# Altium schematic sheet SNP.SchDoc(SNP)
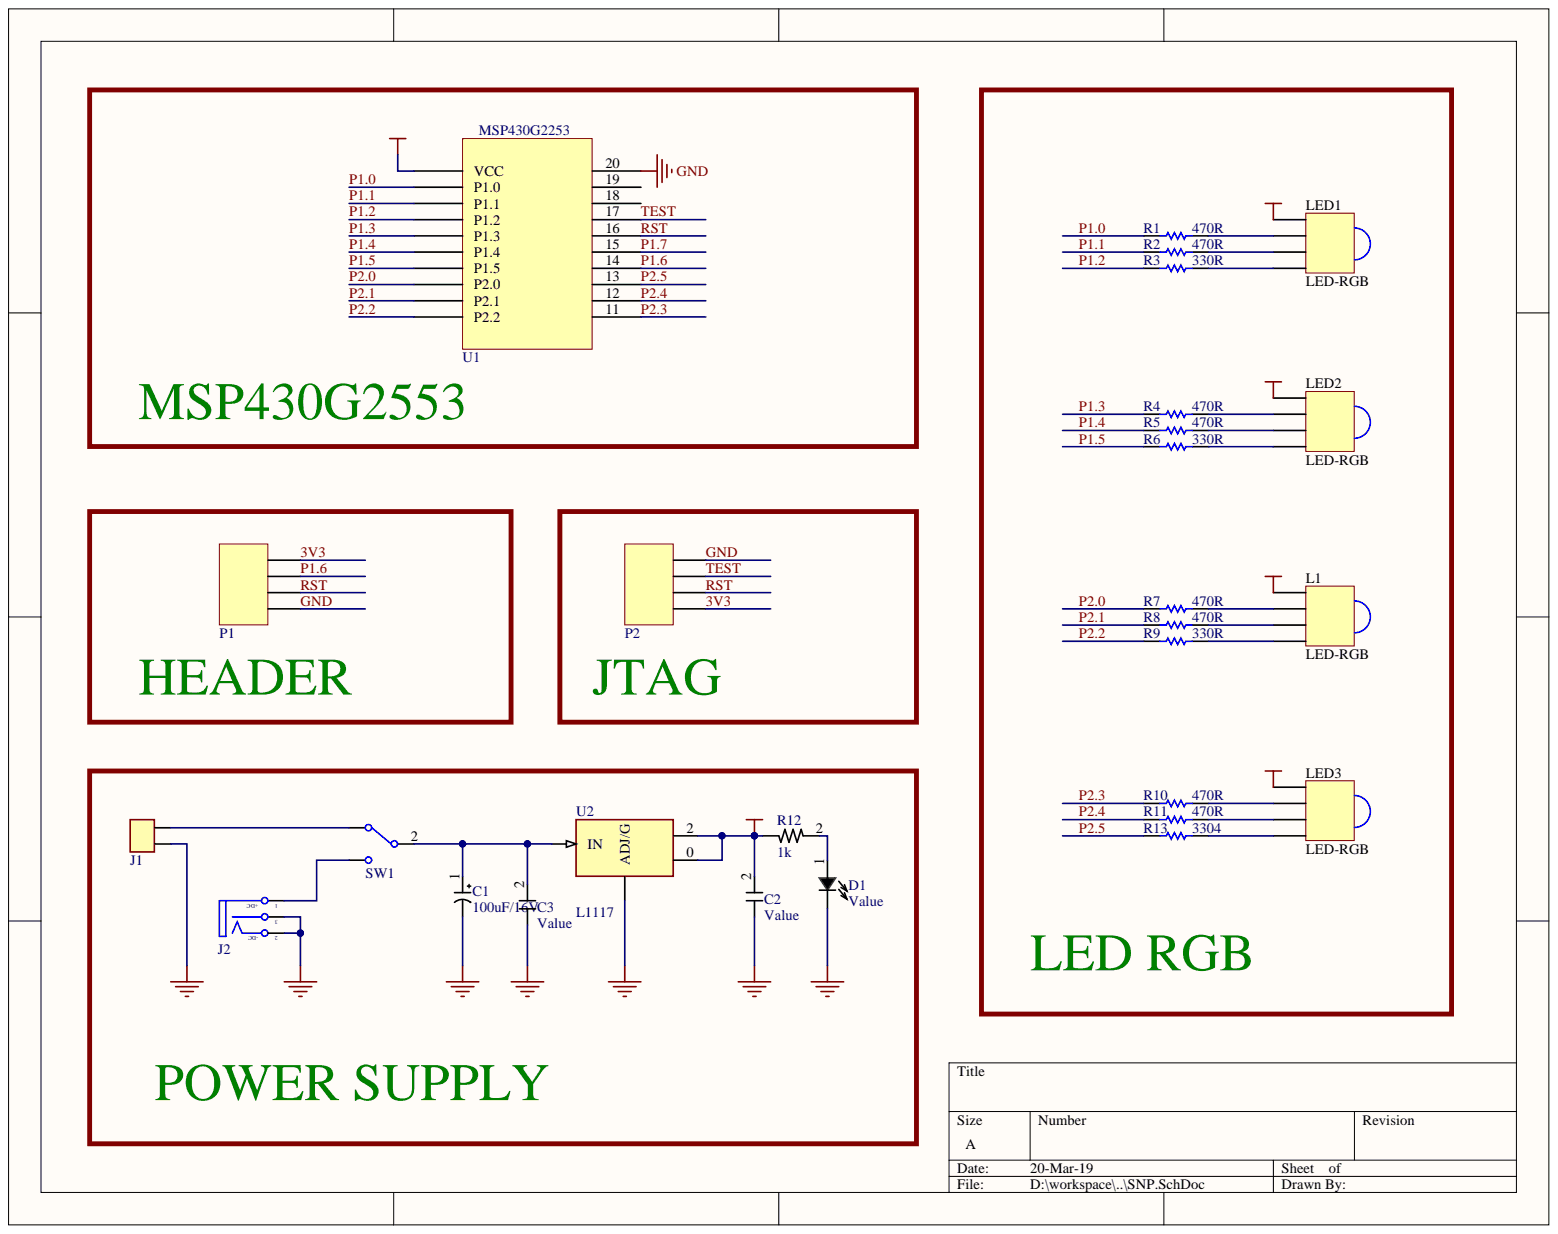
[diagram p0: SNP.SchDoc]

## Parts
C1  value 100uF/16V  [CP1/2 (5x7mm)]  (hidden comment: Cap Pol)
C2  Cap Non Pol  [C0805]  (value not drawn)
C3  Cap Non Pol  [C0805]  (value not drawn)
D1  LED  [LED DIP 3mm]  (value not drawn)
J1  Header 1x2  [Header 1x2 Oval]  (value not drawn)
J2  Jack DC  [Jack DC]  (value not drawn)
L1  LED-RGB  [LED-5MMRGBCA]
LED1  LED-RGB  [LED-5MMRGBCA]
LED2  LED-RGB  [LED-5MMRGBCA]
LED3  LED-RGB  [LED-5MMRGBCA]
P1  Header 4  [JP4]  (value not drawn)
P2  Header 4H  [JP4H]  (value not drawn)
R1  value 470R  [RES-SM-0805]  (hidden comment: RESISTOR SMD)
R2  value 470R  [RES-SM-0805]  (hidden comment: RESISTOR SMD)
R3  value 330R  [RES-SM-0805]  (hidden comment: RESISTOR SMD)
R4  value 470R  [RES-SM-0805]  (hidden comment: RESISTOR SMD)
R5  value 470R  [RES-SM-0805]  (hidden comment: RESISTOR SMD)
R6  value 330R  [RES-SM-0805]  (hidden comment: RESISTOR SMD)
R7  value 470R  [RES-SM-0805]  (hidden comment: RESISTOR SMD)
R8  value 470R  [RES-SM-0805]  (hidden comment: RESISTOR SMD)
R9  value 330R  [RES-SM-0805]  (hidden comment: RESISTOR SMD)
R10  value 470R  [RES-SM-0805]  (hidden comment: RESISTOR SMD)
R11  value 470R  [RES-SM-0805]  (hidden comment: RESISTOR SMD)
R12  value 1k  [R 1/4W DIP 400mil]  (hidden comment: Resistor)
R13  value 3304  [RES-SM-0805]  (hidden comment: RESISTOR SMD)
SW1  SW-SPDT  [MTS-103]  (value not drawn)
U1  MSP430G2553  [DIP20/W0.3]
U2  L1117  [SOT223-4N]

## Nets
3V3: C2.2 L1.A LED1.A LED2.A LED3.A P1.4 P2.1 R12.1 U1.1(VCC) U2.0 U2.2
GND: C1.2 C2.1 C3.1 D1.2 J1.1 J2.2 J2.3 P1.1 P2.4 U1.20 U2.1(ADJ/G)
P1.0: R1.1 U1.2(P1.0)
P1.1: R2.1 U1.3(P1.1)
P1.2: R3.1 U1.4(P1.2)
P1.3: R4.1 U1.5(P1.3)
P1.4: R5.1 U1.6(P1.4)
P1.5: R6.1 U1.7(P1.5)
P1.6: P1.3 U1.14
P1.7: U1.15
P2.0: R7.1 U1.8(P2.0)
P2.1: R8.1 U1.9(P2.1)
P2.2: R9.1 U1.10(P2.2)
P2.3: R10.1 U1.11
P2.4: R11.1 U1.12
P2.5: R13.1 U1.13
RST: P1.2 P2.2 U1.16
TEST: P2.3 U1.17
NetC1_1: C1.1 C3.2 SW1.2 U2.3(IN)
NetD1_1: D1.1 R12.2
NetJ1_2: J1.2 SW1.1
NetJ2_1: J2.1 SW1.3
NetL1_B: L1.B R8.2
NetL1_G: L1.G R7.2
NetL1_R: L1.R R9.2
NetLED1_B: LED1.B R2.2
NetLED1_G: LED1.G R1.2
NetLED1_R: LED1.R R3.2
NetLED2_B: LED2.B R5.2
NetLED2_G: LED2.G R4.2
NetLED2_R: LED2.R R6.2
NetLED3_B: LED3.B R11.2
NetLED3_G: LED3.G R10.2
NetLED3_R: LED3.R R13.2

Not connected: U1 18 19

## Library data of the parts
C1 = Cap Pol: Polarised Capacitor; Library Name: C17_MSP430G2553.SchLib; Component Kind: Standard
C2 C3 = Cap Non Pol: Non Polarised Capacitor; Value: Value; Library Name: C17_MSP430G2553.SchLib; Component Kind: Standard
J1 = Header 1x2: 2.54mm Single Male/Female Pin Header; Library Name: C17_MSP430G2553.SchLib; Component Kind: Standard
J2 = Jack DC: Jack DC input; Library Name: C17_MSP430G2553.SchLib; Component Kind: Standard
P1 = Header 4: Header, 4-Pin; Library Name: PIF_Library.IntLib; LatestRevisionNote: Re-released for DXP Platform.; LatestRevisionDate: 17-Jul-2002; Component Kind: Standard; Publisher: Altium Limited
P2 = Header 4H: Header, 4-Pin, Right Angle; Library Name: PIF_Library.IntLib; LatestRevisionNote: Re-released for DXP Platform.; LatestRevisionDate: 17-Jul-2002; Component Kind: Standard; Publisher: Altium Limited
R1-R11 R13 = RESISTOR SMD: Resistor; Library Name: PIF_Library.IntLib; Component Kind: Standard
SW1 = SW-SPDT: SW 2 Position; Library Name: C17_MSP430G2553.SchLib; Component Kind: Standard
U2 = LM1117: Low dropout (LDO) Voltage Regulator; Value: Value; Library Name: C17_MSP430G2553.SchLib; Component Kind: Standard
D1 = LED: Value: Value; Library Name: C17_MSP430G2553.SchLib; Description: LED; Component Kind: Standard
L1 LED1-LED3 = LED-RGB: Library Name: LIBRARY.IntLib; Component Kind: Standard
R12 = Resistor: Library Name: C17_MSP430G2553.SchLib; Description: Resistor; Component Kind: Standard
U1 = MSP430G2553: Library Name: PIF_Library.IntLib; Component Kind: Standard
